# Altium schematic sheet Relay&LED.SchDoc(Relay&LED)
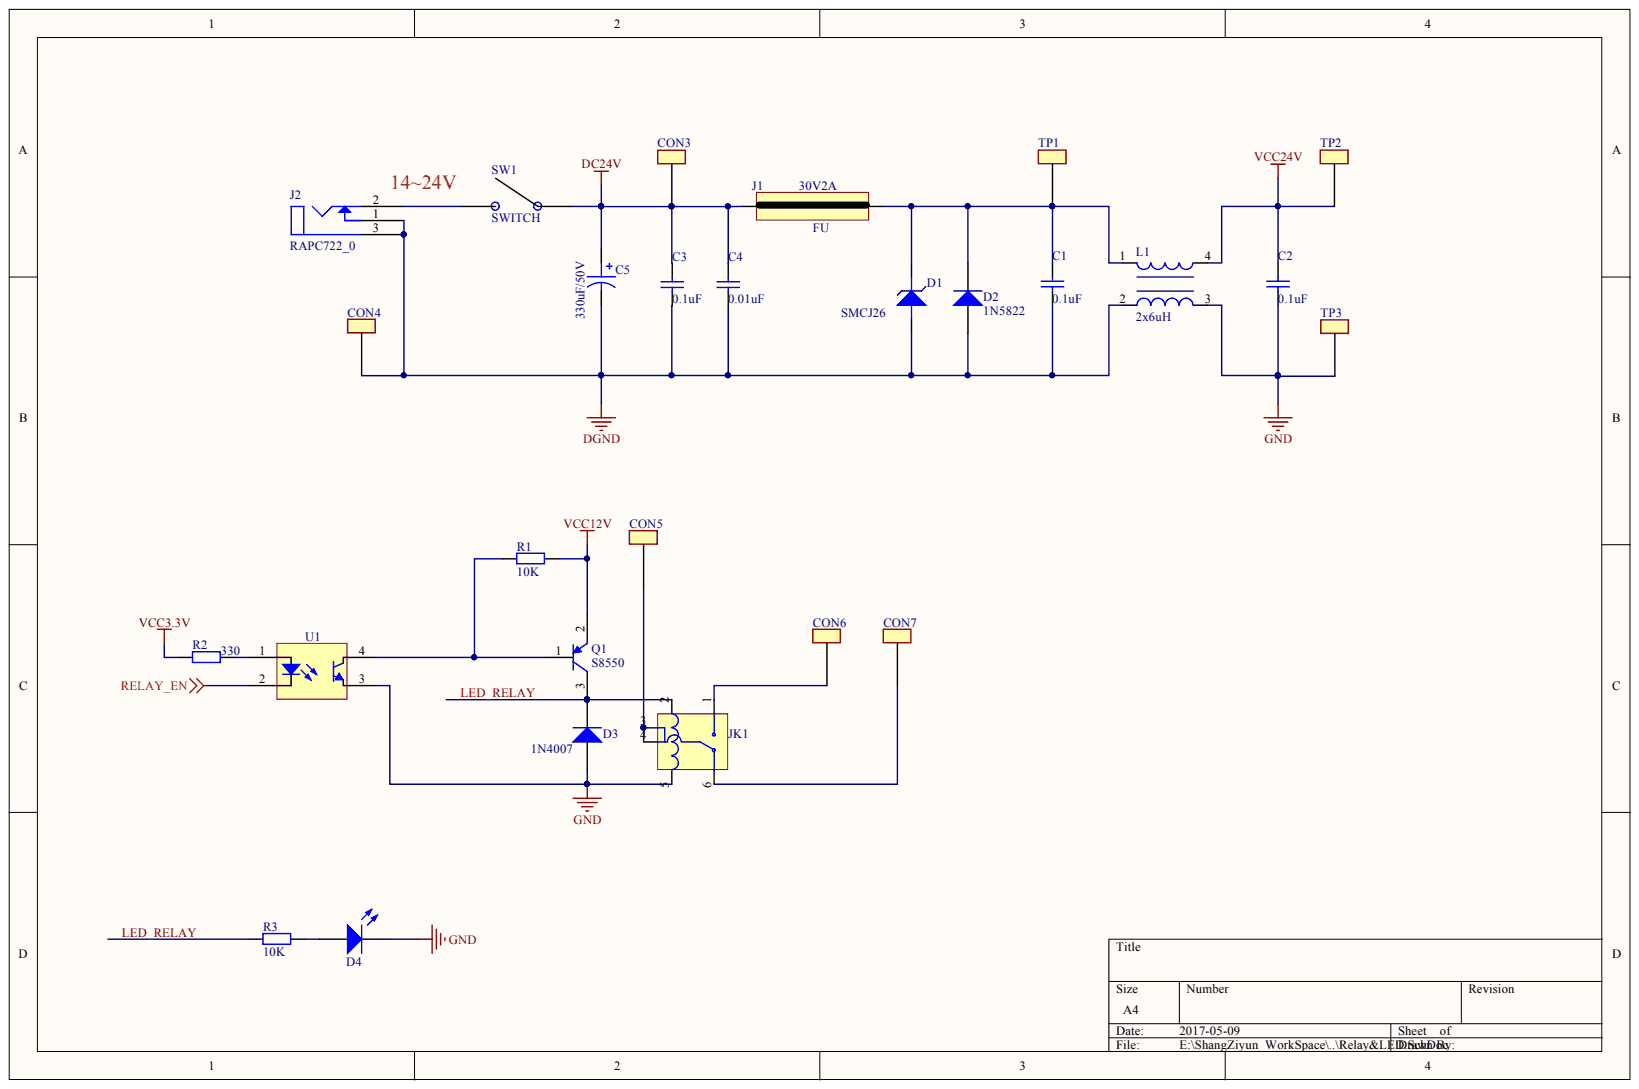
[diagram p0: Relay&LED.SchDoc]

## Parts
C1  value 0.1uF  [0603C]  (hidden comment: Capacitor)
C2  value 0.1uF  [0603C]  (hidden comment: Capacitor)
C3  value 0.1uF  [0603C]  (hidden comment: Capacitor)
C4  value 0.01uF  [0603C]  (hidden comment: Capacitor)
C5  330uF/50V  [CAP10X10]
CON3  TEST POINT_0  [2.8mm_1.2*0.8]  (value not drawn)
CON4  TEST POINT_0  [2.8mm_1.2*0.8]  (value not drawn)
CON5  TEST POINT_0  [2.8mm_1.2*0.8]  (value not drawn)
CON6  TEST POINT_0  [2.8mm_1.2*0.8]  (value not drawn)
CON7  TEST POINT_0  [2.8mm_1.2*0.8]  (value not drawn)
D1  SMCJ26  [DO214AB(SMC)]
D2  1N5822  [DO214AC(SMA)]
D3  1N4007  [DO214AC(SMA)]
D4  LED0  [LED_3mm]  (value not drawn)
J1  FU  value 30V2A  [1812]
J2  RAPC722_0  [RAPC722]
JK1  HK4100F-DC5V  [HK4100F]  (value not drawn)
L1  2x6uH  [JFE100805]
Q1  S8550  [SOT23]
R1  value 10K  [L0603]  (hidden comment: Resistor)
R2  value 330  [L0603]  (hidden comment: Resistor)
R3  value 10K  [L0603]  (hidden comment: Resistor)
SW1  SWITCH  [2EDG-3.5-2P]
TP1  TEST POINT_0  [TP]  (value not drawn)
TP2  TEST POINT_0  [TP]  (value not drawn)
TP3  TEST POINT_0  [2.8mm_1.2*0.8]  (value not drawn)
U1  TLP521-1  [SOP4-2.54]  (value not drawn)

## Nets
DC24V: C3.1 C4.1 C5.1 CON3.1 J1.1 SW1.2
DGND: C1.2 C3.2 C4.2 C5.2 CON4.1 D1.1 D2.1 J2.1 J2.3 L1.2
GND: C2.2 D3.1 D4.2 JK1.5 L1.3 TP3.1 U1.3
RELAY_EN: U1.2
VCC3.3V: R2.1
VCC12V: Q1.2 R1.2
VCC24V: C2.1 L1.4 TP2.1
LED_RELAY: D3.2 JK1.2 Q1.3 R3.2
NetC1_1: C1.1 D1.2 D2.2 J1.2 L1.1 TP1.1
NetCON5_1: CON5.1 JK1.3 JK1.4
NetCON6_1: CON6.1 JK1.1
NetCON7_1: CON7.1 JK1.6
NetD4_1: D4.1 R3.1
NetJ2_2: J2.2 SW1.1
NetQ1_1: Q1.1 R1.1 U1.4
NetR2_2: R2.2 U1.1

## Library data of the parts
C1-C4 = Cap: Component Kind: Standard; Description: Capacitor; LatestRevisionDate: 17-Jul-2002; LatestRevisionNote: Re-released for DXP Platform.; Library Name: Lib1.SCHLIB; PackageDescription: Radial Cap, Thru-Hole; 2 Leads; 0.3 in Pin Spacing; PackageReference: RAD-0.3; Published: 8-Jun-2000; Signal Integrity: Cap; Simulation: CAP; Publisher: Altium Limited
C5 = CAPACITOR POL_3: Value: 330uF/50V铝电解; Component Kind: Standard; Library Name: SENSOR_BOARD_Library.SchLib
D1 = SMCJ6_0.0A: Component Kind: Standard; Value: SMCJ26; Library Name: 简配版生物罐.SchLib
D2 D3 = Diode 1N4007: 1 Amp General Purpose Rectifier; Code_JEDEC: DO-204-AL; Component Kind: Standard; ComponentLink1Description: Manufacturer Link; ComponentLink1URL: http://www.fairchildsemi.com/; ComponentLink2Description: Datasheet; ComponentLink2URL: http://www.fairchildsemi.com/ds/1N%2F1N4001.pdf; DatasheetDocument: 1998; LatestRevisionDate: 17-Jul-2002; LatestRevisionNote: Re-released for DXP Platform.; PackageDocument: Aug-1999; PackageReference: DO-41; Published: 8-Jun-2000; Signal Integrity: 1N4007; Simulation: 1N4007; Publisher: Altium Limited
  D2: Value: 1N5822; Library Name: 简配版生物罐_1.SCHLIB
  D3: Library Name: Miscellaneous Devices.IntLib
D4 = LED0805: Typical INFRARED GaAs LED; Value: LED_3mm_RED; Component Kind: Standard; LatestRevisionDate: 08-Jul-2005; LatestRevisionNote: Mech Layer 1 removed.; PackageDescription: LED; 2 Leads; PackageReference: LED-0; Published: 8-Jun-2000; Library Name: 简配版生物罐.SCHLIB; Publisher: Altium Limited
J2 = RAPC722_0: MDSPARTNO: J0368; Mfg: Switchcraft; Component Kind: Standard; Library Name: MAINBOARD_Library.SchLib; Mfg Part No: RAPC722; RoHS: y; Value: RAPC722
JK1 = HK4100F-DC5V: Value: HK4100F 12V继电器; Component Kind: Standard; Library Name: LNG.SCHLIB
L1 = CommonmodeChoke: Value: 100805贴片电感; Component Kind: Standard; Library Name: MyLib.SCHLIB
Q1 = PNP: Component Kind: Standard; Value: S8550; Library Name: LNG.SCHLIB
R1-R3 = Res: Component Kind: Standard
  R3: Library Name: Lib1.SCHLIB
  R1 R2: Library Name: LNG.SCHLIB
SW1 = SWITCH: Value: 2EDG-3.5-2P; Component Kind: Standard; Library Name: 简配版生物罐.SchLib
U1 = TLP521-1: Value: PC817; Component Kind: Standard; Library Name: LNG.SCHLIB
CON3-CON7 TP1-TP3 = TEST POINT_0: Component Kind: Standard; Library Name: MAINBOARD_Library.SchLib
J1 = FU: Component Kind: Standard; Library Name: 简配版生物罐.SCHLIB
